# Altium schematic sheet lv_power_indicator_light_cc.SchDoc(lv_power_indicator_light_cc)
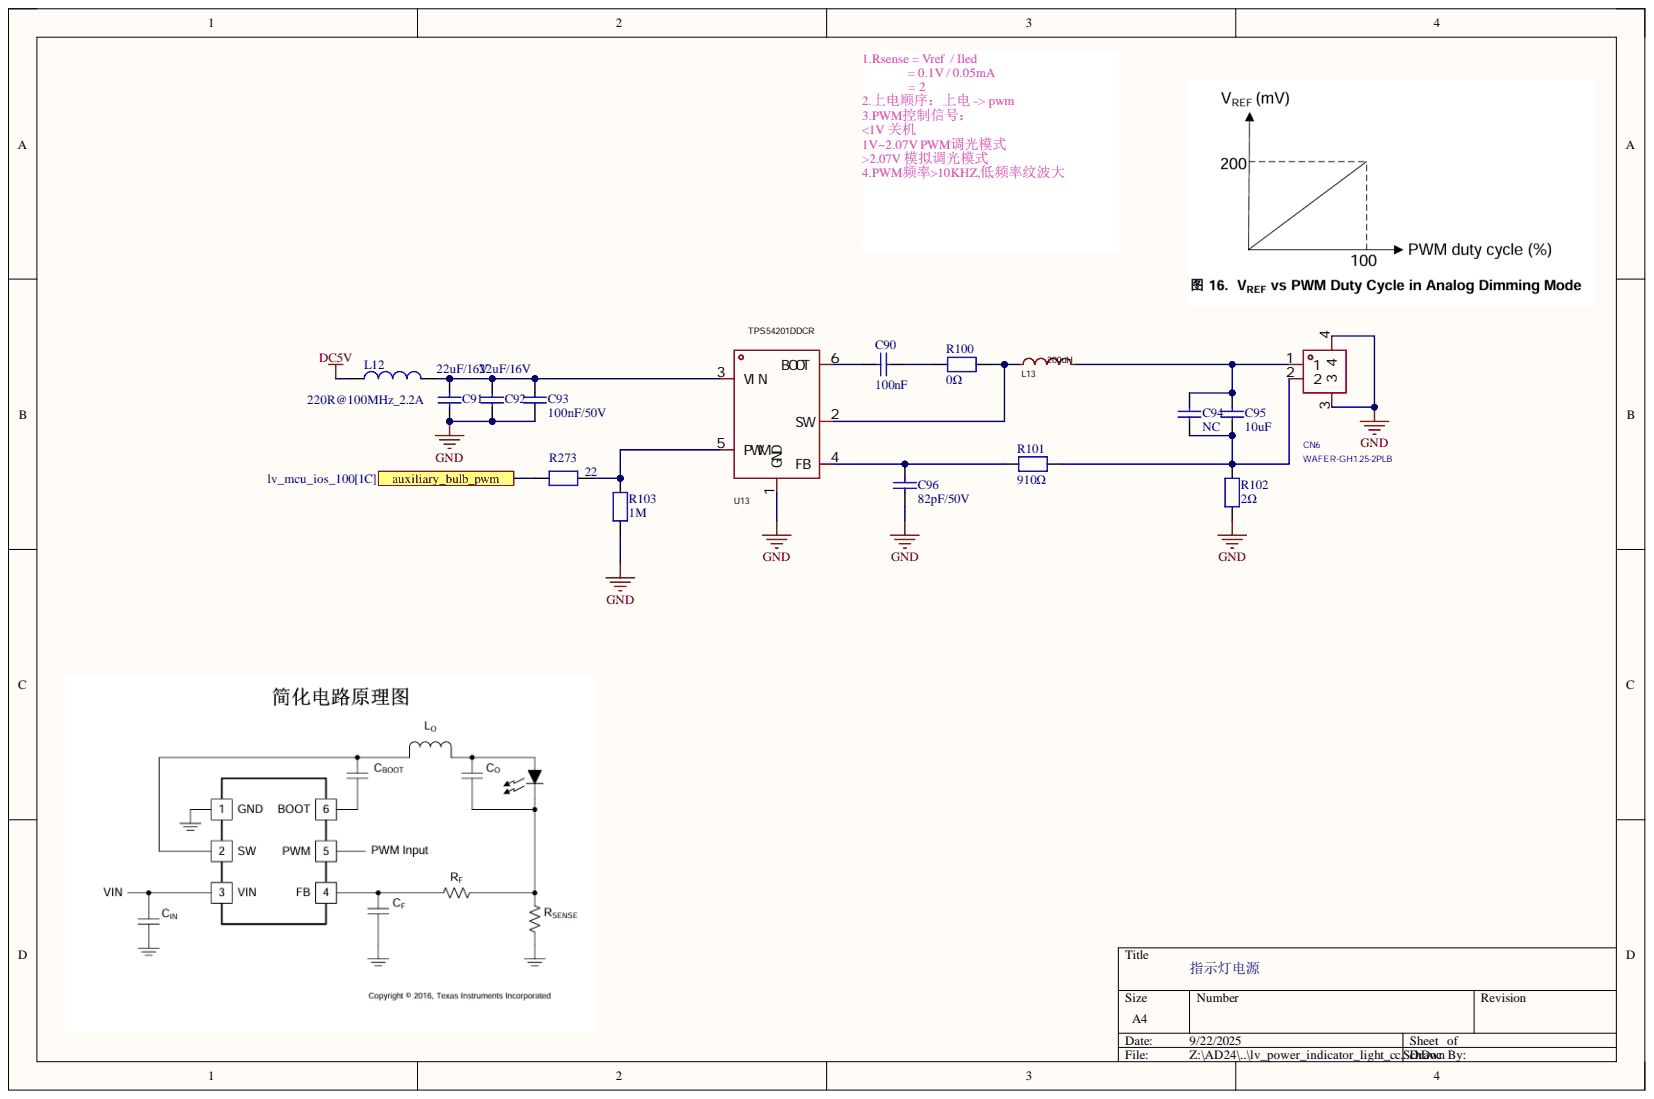
[diagram p0: lv_power_indicator_light_cc.SchDoc]

## Parts
C90  100nF  [C0402]
C91  22uF/16V  [C1206]
C92  22uF/16V  [C1206]
C93  100nF/50V  [C0402]
C94  NC  [C0603]
C95  10uF  [C0603]
C96  82pF/50V  [C0402]
CN6  WAFER-GH1.25-2PLB  [CONN-TH_2P-P1.25_XUNPU_WAFER-GH1.25-2PLB]
L12  220R@100MHz_2.2A  [L0603]
L13  200uH  [IND-SMD_L5.0-W5.0_FNR50XXS]
R100  0Ω  [R0603]
R101  910Ω  [R0603]
R102  2Ω  [0805]
R103  1M  [R0603]
R273  22  [R0402]
U13  TPS54201DDCR  [TSOT-23-6_L2.9-W1.6-P0.95-LS2.8-BR]

## Ports
auxiliary_bulb_pwm

## Nets
DC5V: L12.2
GND: C91.1 C92.1 C93.2 C96.2 CN6.3 CN6.4 R102.2 R103.1 U13.1(GND)
auxiliary_bulb_pwm: R273.1 ; port auxiliary_bulb_pwm
NetC90_1: C90.1 U13.6(BOOT)
NetC90_2: C90.2 R100.2
NetC91_2: C91.2 C92.2 C93.1 L12.1 U13.3(VIN)
NetC94_1: C94.1 C95.1 CN6.2 R101.1 R102.1
NetC94_2: C94.2 C95.2 CN6.1 L13.2
NetC96_1: C96.1 R101.2 U13.4(FB)
NetL13_1: L13.1 R100.1 U13.2(SW)
NetR103_2: R103.2 R273.2 U13.5(PWM)

## Library data of the parts
C90 = Capacitor: 50V, 5%, X7R; Manufacturer: muRata; Manufacturer_Part_Number: GRM155R71H104KE14D; Component Kind: Standard; ComponentLink1Description: Datasheet; ComponentLink1URL: http://tunmcdsvn.tun.st.com/Component_Datasheet_Library/Trunk/Capacitors/Yageo_Capacitor_NP0_16V-to-50V; Library Name: HiPin_Library_AD.IntLib
C91 C92 = Capacitor_1: 16V, X5R, 10%; Internal_Part_Number: MCD-00009; Manufacturer_Part_Number: GRM31CR61C226KE15L; Component Kind: Standard; ComponentLink1Description: Yageo_Capacitor_X5R-series.pdf; ComponentLink1URL: http://tunmcdsvn.tun.st.com/Component_Datasheet_Library/Trunk/Capacitors/Yageo_Multilayer_capacitor_X5R_series_100pF_to_100uF; Library Name: HiPin_Library_AD.IntLib
C93 = Capacitor_2: 50V, X7R , 10%; Manufacturer: muRata; Manufacturer_Part_Number: GRM155R71H104KE14D; Component Kind: Standard; ComponentLink1Description: Datasheet; ComponentLink1URL: http://tunmcdsvn.tun.st.com/Component_Datasheet_Library/Trunk/Capacitors/Yageo_Multilayer_capacitor_X5R_series_100pF_to_100uF; Library Name: HiPin_Library_AD.IntLib
C94 C95 = Capacitor_1: 25V, X5R, 20%; Manufacturer: muRata; Manufacturer_Part_Number: GRM188R61E106MA73D; Component Kind: Standard; ComponentLink1Description: Yageo_Capacitor_X5R-series.pdf; ComponentLink1URL: http://tunmcdsvn.tun.st.com/Component_Datasheet_Library/Trunk/Capacitors/Yageo_Multilayer_capacitor_X5R_series_100pF_to_100uF; Library Name: HiPin_Library_AD.IntLib
C96 = Capacitor: 50V,1%, NP0; Manufacturer: YAGEO; Manufacturer_Part_Number: CC0402FRNPO9BN820; Component Kind: Standard; Library Name: HiPin_Library_AD.IntLib
CN6 = WAFER-GH1.25-2PLB: 插座系列: GH,  立贴结构: 1x2P, 间距: 1.25mm; Manufacturer: XUNPU(讯普); Add into BOM: yes; Current Rating: 1A; Number of PINs Per Row: 2; Plastic Material: LCP; Supplementary Features: 辅助焊脚; Voltage Rating: 125V; X-Length of Bottom Edge on Board (Spacing Line): 5.75mm; Y-Width of Bottom Edge on Board: 4.95mm; Z-Height of the Board: 4.2mm; Color: 米色; Component Kind: Standard; Contact Material: 黄铜; Contact Plating: -; Convert to PCB: yes; Datasheet: https://atta.szlcsc.com/upload/public/pdf/source/20220527/44F824AAC8C2B617205E15955FF9C23D.pdf; Device: WAFER-GH1.25-2PLB; Flame Retardant Rating: -; JLCPCB Part Class: Extended Part; LCSC Part Name: 1x2P 间距:1.25mm 立贴 系列:GH; Library Name: HiPin_Library_AD.IntLib; Manufacturer Part: WAFER-GH1.25-2PLB; Mounting Type: 立贴; Number of Pins: 2P; Number of Rows: 1; Operating Temperature: -25℃~+85℃; PCB3D: CONN-TH_2P-P1.25_XUNPU_WAFER-GH1.25-2PLB; Pins Structure: 1x2P; Pitch: 1.25mm; Reference Series: GH; Row Spacing: -; Supplier: LCSC; Supplier Footprint: SMD,P=1.25mm; Supplier Part: C3029368; Symbol: WAFER-GH1.25-2PLB
L12 = MCD-00047: SMD Chip ferrite bead, 220R@100MHz +/-25%,2.2A, 50mohm; Manufacturer: Sunlord; Manufacturer_Part_Number: UPZ1608U221-2R2TF; Database Table Name: MCD_PARTS$; Component Kind: Standard; ComponentLink1Description: Datasheet; ComponentLink1URL: http://tunmcdsvn.tun.st.com/Component_Datasheet_Library/Trunk/Inductors/TaiTech_Bead_FCM1608_series; Internal_Part_Number: MCD-00047; Library Name: MCD_PARTS_database.DbLib
L13 = FNR5020S201MT: Manufacturer: cjiang(长江微电); Add into BOM: yes; DC Resistance (DCR): 2.6Ω; Rated Current: 450mA; Saturation Current (Isat): 330mA; Tolerance: ±20%; Component Kind: Standard; Convert to PCB: yes; Datasheet: https://atta.szlcsc.com/upload/public/pdf/source/20190327/C167925_9EFF0AABC4CA629C2CC95961DBF7D39D.pdf; Device: FNR5020S201MT; JLCPCB Part Class: Extended Part; LCSC Part Name: 200uH ±20% 330mA; Library Name: HiPin_Library_AD.IntLib; Manufacturer Part: FNR5020S201MT; Supplier: LCSC; Supplier Footprint: SMD,5x5mm; Supplier Part: C167949; Symbol: FNR5020S201MT; Value: 200uH
R100-R103 R273 = RES: 1%; Value: 10K; Component Kind: Standard; Library Name: HiPin_Library_AD.IntLib
U13 = TPS54201DDCR: Manufacturer: TI(德州仪器); Add into BOM: yes; Channels: 1; Topology: 降压; Component Kind: Standard; Convert to PCB: yes; Datasheet: https://www.ti.com/cn/lit/gpn/tps54201; Device: TPS54201DDCR; JLCPCB Part Class: Extended Part; LCSC Part Name: TPS54201DDCR; Library Name: HiPin_Library_AD.IntLib; Manufacturer Part: TPS54201DDCR; Operating temperature: -40℃~+125℃; Supplier: LCSC; Supplier Footprint: TSOT-23-6; Supplier Part: C841634; Symbol: TPS54201DDCR
